# Altium schematic sheet COMMUNICATION(1).SchDoc(COMMUNICATION(1))
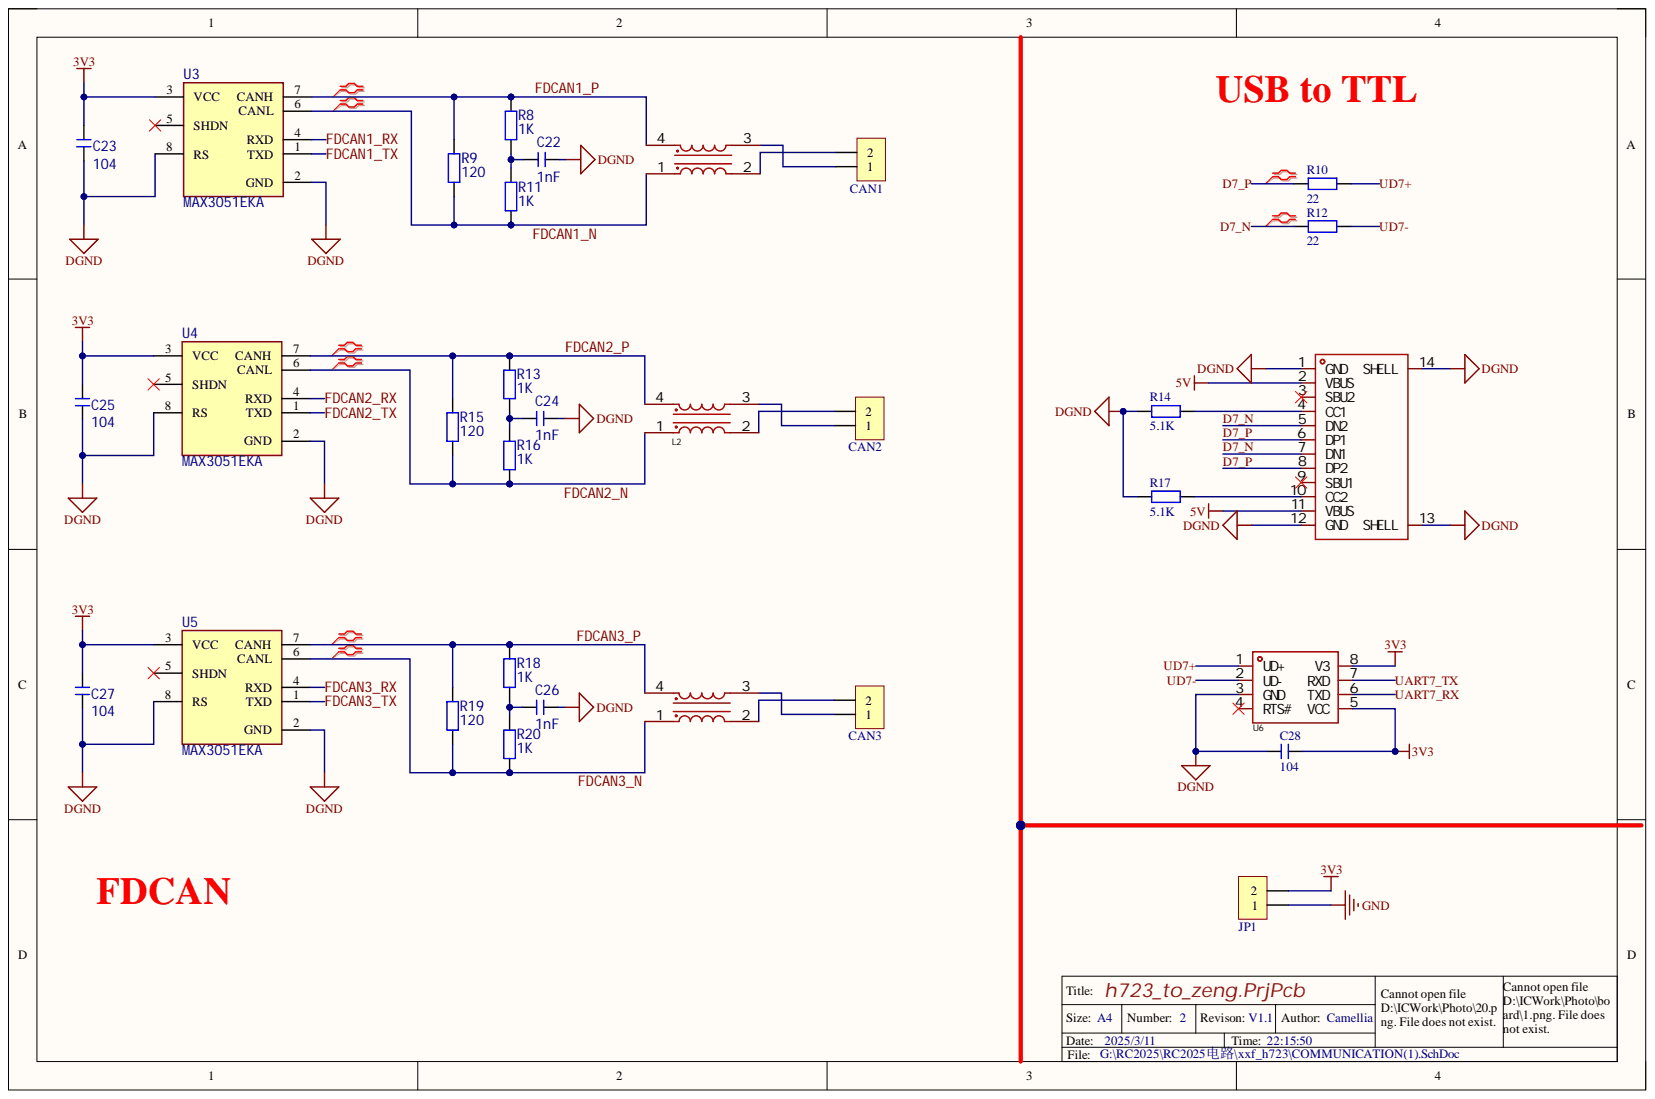
[diagram p0: COMMUNICATION]

## Parts
C22  1nF  [C 0603_L]
C23  104  [C 0603_L]
C24  1nF  [C 0603_L]
C25  104  [C 0603_L]
C26  1nF  [C 0603_L]
C27  104  [C 0603_L]
C28  104  [C 0603_L]
CAN1  HDR-1X2  [CONN-TH_WJ15EDGVC-3.81-2P]  (value not drawn)
CAN2  HDR-1X2  [CONN-TH_WJ15EDGVC-3.81-2P]  (value not drawn)
CAN3  HDR-1X2  [CONN-TH_WJ15EDGVC-3.81-2P]  (value not drawn)
JP1  HDR-1X2  [HDR2.54-LI-2P]  (value not drawn)
L1  DLW32SH101XF2L  [FILTER-SMD_4P-L3.2-W2.5-BL_DLW32SH510XK2L]  (value not drawn)
L2  DLW32SH101XF2L  [FILTER-SMD_4P-L3.2-W2.5-BL_DLW32SH510XK2L]  (value not drawn)
L3  DLW32SH101XF2L  [FILTER-SMD_4P-L3.2-W2.5-BL_DLW32SH510XK2L]  (value not drawn)
R8  1K  [R 0603_L]
R9  120  [R 0603_L]
R10  22  [R 0603_L]
R11  1K  [R 0603_L]
R12  22  [R 0603_L]
R13  1K  [R 0603_L]
R14  5.1K  [R 0603_L]
R15  120  [R 0603_L]
R16  1K  [R 0603_L]
R17  5.1K  [R 0603_L]
R18  1K  [R 0603_L]
R19  120  [R 0603_L]
R20  1K  [R 0603_L]
U3  MAX3051EKA  [Texas_Instruments_DCN0008A_IPC_C]
U4  MAX3051EKA  [Texas_Instruments_DCN0008A_IPC_C]
U5  MAX3051EKA  [Texas_Instruments_DCN0008A_IPC_C]
U6  CH340N  [SOP8_N]  (value not drawn)
USB-TTL1  TYPE-C 16PIN 2MD(073)  [USB-C-SMD_TYPE-C-6PIN-2MD-073]  (value not drawn)

## Nets
3V3: C23.2 C25.2 C27.2 C28.2 JP1.2 U3.3(VCC) U4.3(VCC) U5.3(VCC) U6.5(VCC) U6.8(V3)
5V: USB-TTL1.2(VBUS) USB-TTL1.11(VBUS)
DGND: C22.1 C23.1 C24.1 C25.1 C26.1 C27.1 C28.1 R14.1 R17.1 U3.2(GND) U3.8(RS) U4.2(GND) U4.8(RS) U5.2(GND) U5.8(RS) U6.3(GND) USB-TTL1.1(GND) USB-TTL1.12(GND) USB-TTL1.13(SHELL) USB-TTL1.14(SHELL)
GND: JP1.1
D7_N: R12.1 USB-TTL1.5(DN2) USB-TTL1.7(DN1)
D7_P: R10.1 USB-TTL1.6(DP1) USB-TTL1.8(DP2)
FDCAN1_N: L1.1 R9.1 R11.1 U3.6(CANL)
FDCAN1_P: L1.4 R8.2 R9.2 U3.7(CANH)
FDCAN1_RX: U3.4(RXD)
FDCAN1_TX: U3.1(TXD)
FDCAN2_N: L2.1 R15.1 R16.1 U4.6(CANL)
FDCAN2_P: L2.4 R13.2 R15.2 U4.7(CANH)
FDCAN2_RX: U4.4(RXD)
FDCAN2_TX: U4.1(TXD)
FDCAN3_N: L3.1 R19.1 R20.1 U5.6(CANL)
FDCAN3_P: L3.4 R18.2 R19.2 U5.7(CANH)
FDCAN3_RX: U5.4(RXD)
FDCAN3_TX: U5.1(TXD)
UART7_RX: U6.6(TXD)
UART7_TX: U6.7(RXD)
UD7+: R10.2 U6.1(UD+)
UD7-: R12.2 U6.2(UD-)
NetC22_2: C22.2 R8.1 R11.2
NetC24_2: C24.2 R13.1 R16.2
NetC26_2: C26.2 R18.1 R20.2
NetCAN1_1: CAN1.1 L1.3
NetCAN1_2: CAN1.2 L1.2
NetCAN2_1: CAN2.1 L2.3
NetCAN2_2: CAN2.2 L2.2
NetCAN3_1: CAN3.1 L3.3
NetCAN3_2: CAN3.2 L3.2
NetR14_2: R14.2 USB-TTL1.4(CC1)
NetR17_2: R17.2 USB-TTL1.10(CC2)

Not connected: U3 5(SHDN); U4 5(SHDN); U5 5(SHDN); U6 4(RTS#); USB-TTL1 3(SBU2) 9(SBU1)

## Library data of the parts
C22-C28 = C: 无极性贴片电容; 材质: X7R; BOM名称: 无极性贴片电容; Component Kind: Standard; Library Name: 3.1   - 电阻-电容-电感.SchLib
CAN1-CAN3 JP1 = Header 2: 2P接插件; BOM名称: 2P接插件; Component Kind: Standard; Library Name: 4.6   - 接插件-脚距2.54.SchLib
L1-L3 = DLW32SH101XF2L: Manufacturer: muRata(村田); Add into BOM: yes; Current Rating: 115mA; DC Resistance (DCR): 2.1Ω; Inductance @ Frequency: 100uH@0.1MHz; Number of Lines: 2; Voltage Rating - DC: 50V; Withstanding Voltage: 125V; Component Kind: Standard; Convert to PCB: yes; Datasheet: https://atta.szlcsc.com/upload/public/pdf/source/20210610/2268469287CE9D7A9FE0DD2542B86502.pdf; Device: DLW32SH101XF2L; JLCPCB Part Class: Extended Part; LCSC Part Name: 两路 共模滤波器; Manufacturer Part: DLW32SH101XF2L; Operating Temperature: -40℃~+125℃; PCB3D: FILTER-SMD_4P-L3.2-W2.5-BL_DLW32SH510XK2L; Supplier: LCSC; Supplier Footprint: -; Supplier Part: C1857795; Symbol: DLW32SH101XF2L
R8-R20 = R: 贴片电阻; BOM名称: 贴片电阻; Component Kind: Standard; Library Name: 3.1   - 电阻-电容-电感.SchLib
U3-U5 = MAX3051EKA: CAN 1Mbps Shutdown/Standby 3.3V 8-Pin SOT-23 T/R; Component Kind: Standard; Library Name: 1.1   - IC芯片.SchLib
U6 = CH340N: Manufacturer: WCH(南京沁恒); Add into BOM: yes; Symbol: CH340N_C2977777; Component Kind: Standard; Convert to PCB: yes; Datasheet: https://atta.szlcsc.com/upload/public/pdf/source/20230530/6E401E9554E9F5311886CC8B3F89B62E.pdf; Device: CH340N; JLCPCB Part Class: Extended Part; LCSC Part Name: USB转串口芯片 CH340; Library Name: main_controller_f446.SchLib; Manufacturer Part: CH340N; Supplier: LCSC; Supplier Footprint: SOP-8; Supplier Part: C2977777
USB-TTL1 = TYPE-C 16PIN 2MD(073): Manufacturer: SHOU HAN(首韩); Add into BOM: yes; Connect-Disconnect Life: 5千次; Core Colour: 黑色; Current Rating - Power (Max): 5A; Gender: 母; Number of Contacts: 16P; Number of Ports: 1; Rated Voltage (Max): 5V; Component Kind: Standard; Connector Type: Type-C; Convert to PCB: yes; Datasheet: https://atta.szlcsc.com/upload/public/pdf/source/20211123/39B17C16241EAC15C5E277BDA434118E.pdf; Device: TYPE-C 16PIN 2MD(073); JLCPCB Part Class: Extended Part; LCSC Part Name: Type-C 母 卧贴; Library Name: 4.6   - 接插件-脚距2.54.SchLib; Manufacturer Part: TYPE-C 16PIN 2MD(073); Mounting Style: 卧贴; Operating Temperature Range: -25℃~+85℃; Standard: USB 3.1; Supplier: LCSC; Supplier Footprint: SMD; Supplier Part: C2765186; Symbol: TYPE-C 16PIN 2MD(073)
